# Altium schematic sheet 12 - Back Page.SchDoc("12 - Back Page")
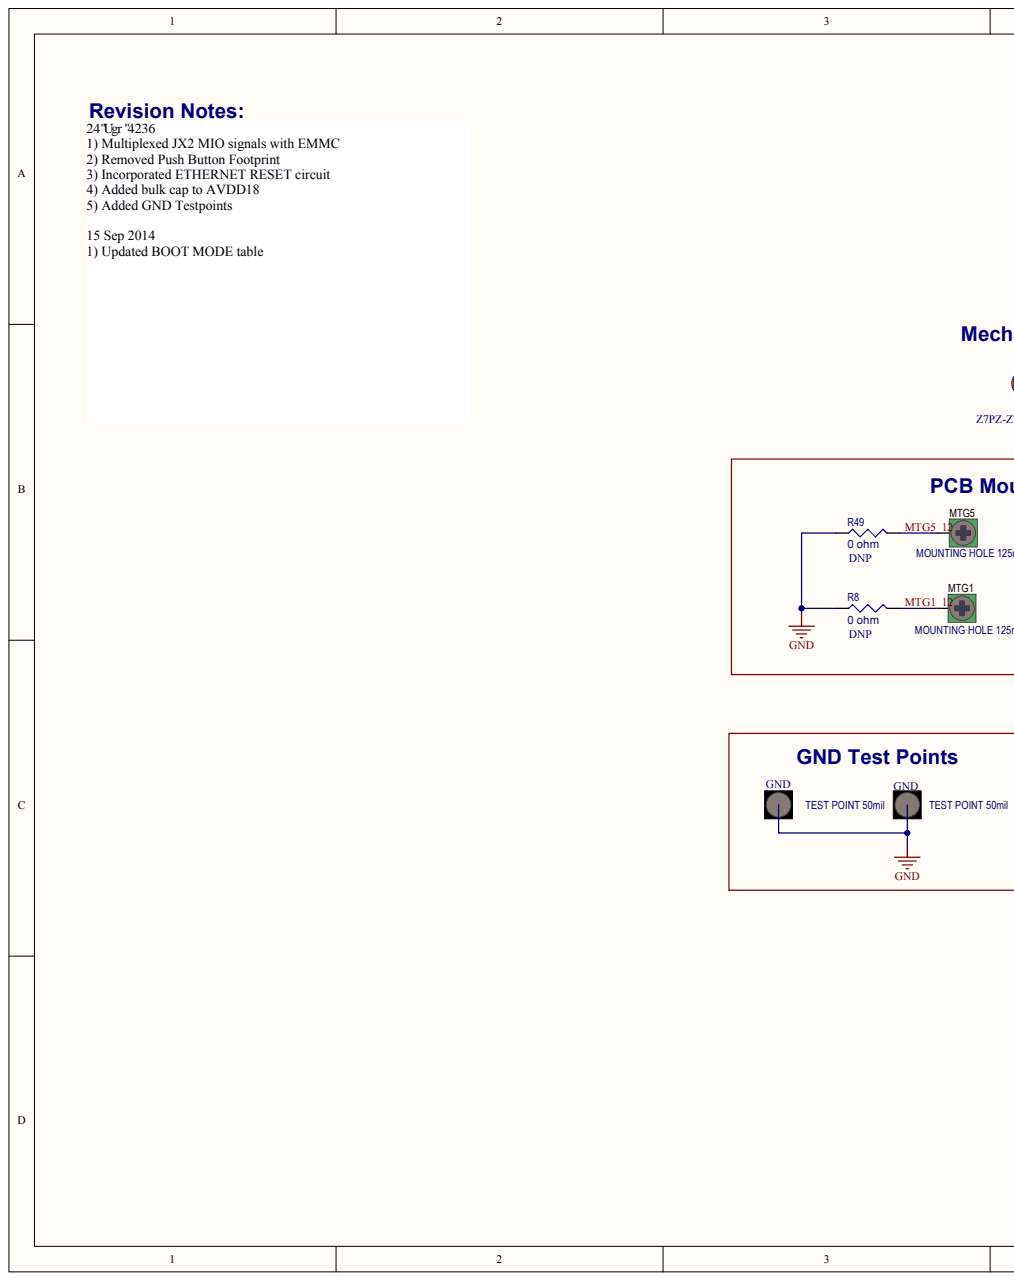
[diagram p0: 12 - Back Page.SchDoc, left]
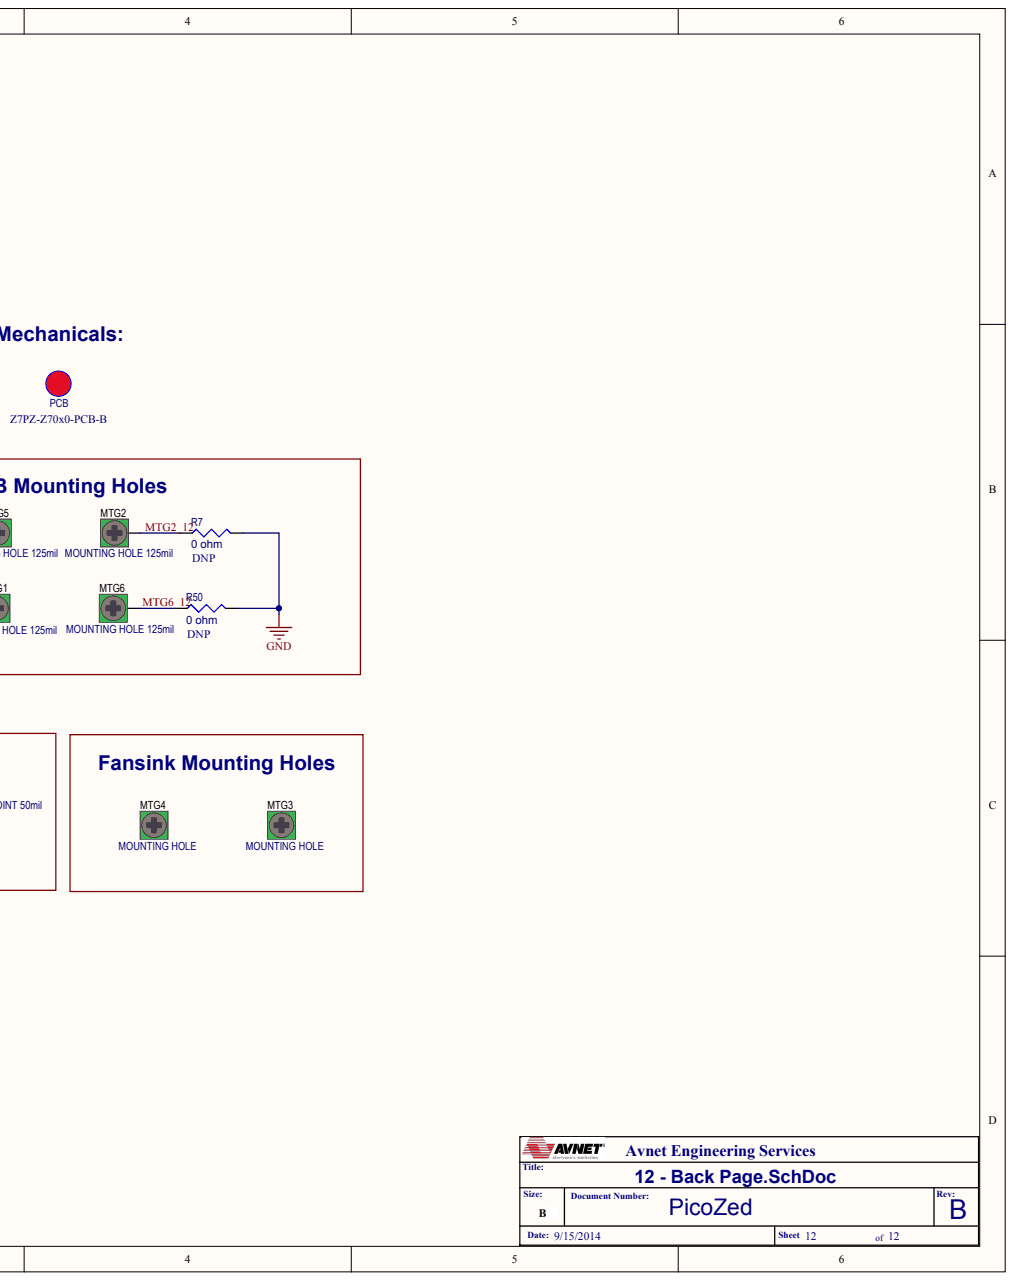
[diagram p1: 12 - Back Page.SchDoc, right]

## Parts
BPH1  PCB  MFGPN: Z7PZ-Z70x0-PCB-B
MTG1  MOUNTING HOLE 125mil
MTG2  MOUNTING HOLE 125mil
MTG3  MOUNTING HOLE
MTG4  MOUNTING HOLE
MTG5  MOUNTING HOLE 125mil
MTG6  MOUNTING HOLE 125mil
R7  0 ohm
R8  0 ohm
R49  0 ohm
R50  0 ohm
TP1  TEST POINT 50mil
TP2  TEST POINT 50mil

## Nets
GND: R7.1 R8.2 R49.2 R50.1 TP1.0 TP2.0
MTG1_12: MTG1.0 R8.1  [also labelled MTG1]
MTG2_12: MTG2.0 R7.2  [also labelled MTG2]
MTG5_12: MTG5.0 R49.1  [also labelled MTG5]
MTG6_12: MTG6.0 R50.2  [also labelled MTG6]

## Library data of the parts
BPH1 = (no symbol name): MFG Code: AVNET; AVLNo: N/A
MTG1-MTG6 = (no symbol name): AES Part #: AVDNP-0000003; Category: Mounting-Hole; Status: Production
R7 R8 R49 R50 = (no symbol name): PartCode: PR; AVLNo: AVPR-0000027; PkgType: SM; AsyTxt: PR027; Watt: 62mW; Device: RES; MFG Code: DAL; MFGPN: CRCW04020000Z0ED; Value: 0 ohm; RoHS: Yes; Status: Preliminary; ComponentLink1Description: Datasheet; DNP: DNP; ComponentLink1URL: C:\AVDBLIB\DATASHEETS\Dale_CRCW.pd
TP1 TP2 = (no symbol name): Category: Test Point; Status: Production; GND: GND
